# Altium schematic sheet Audio.SchDoc
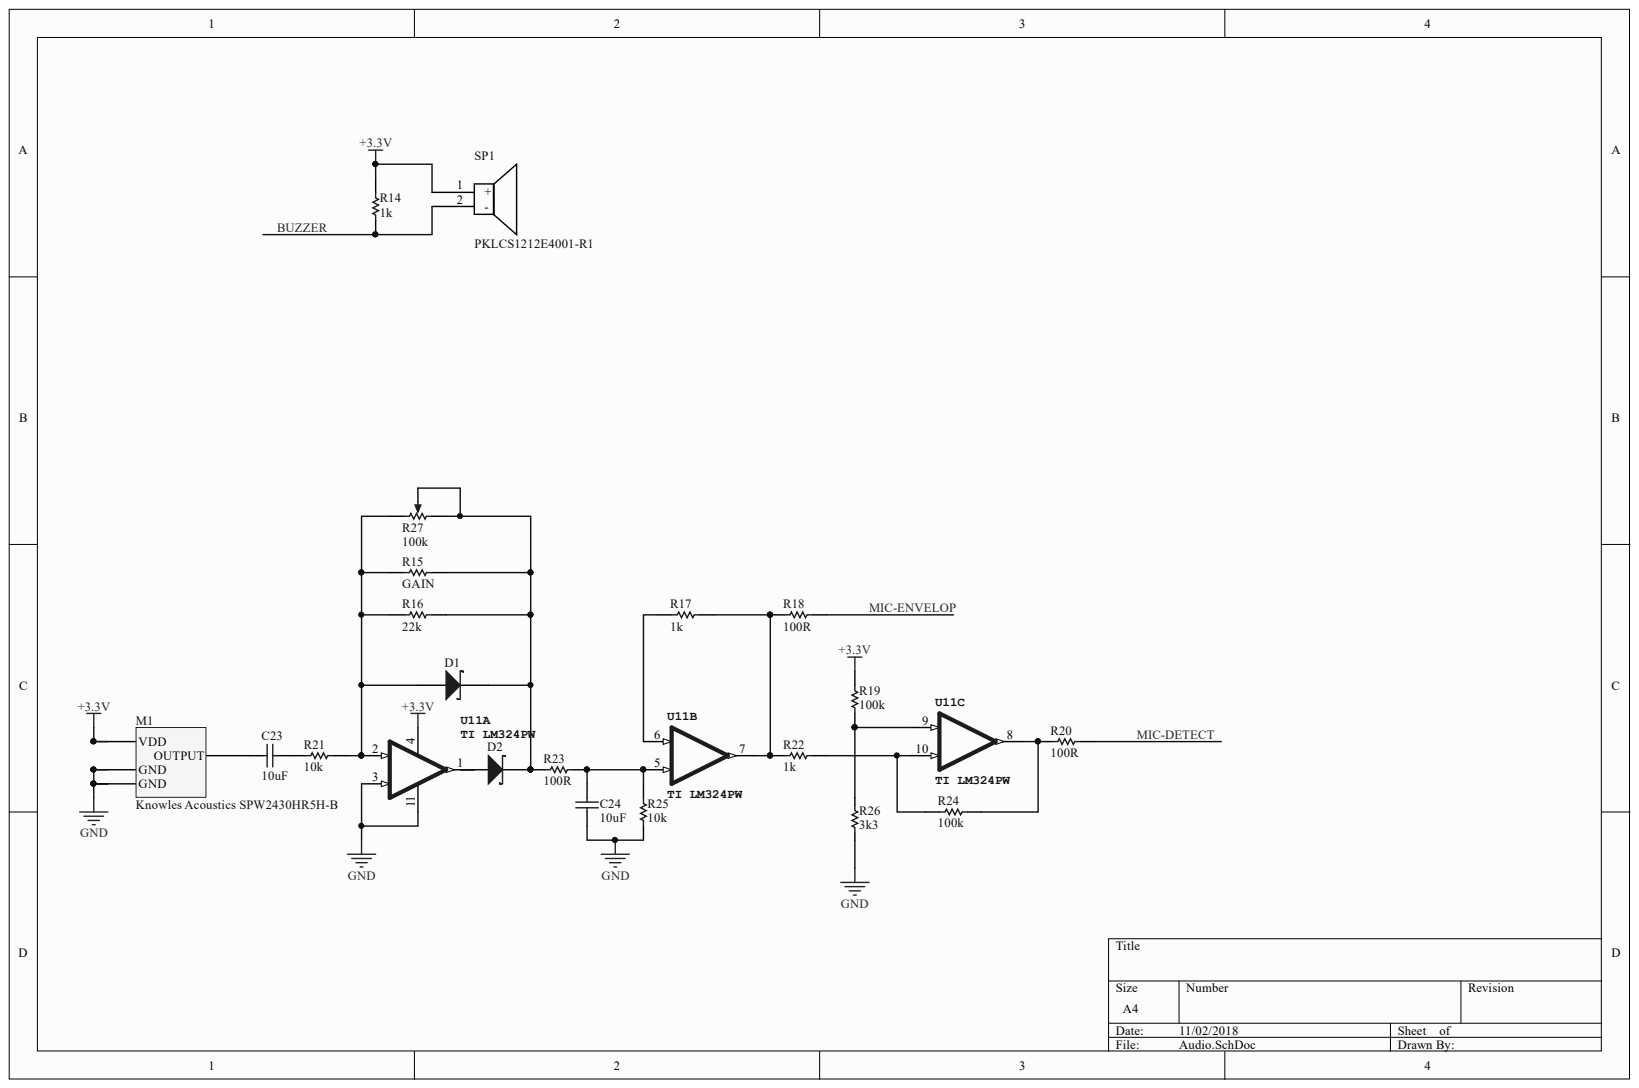
[diagram p0: Audio.SchDoc]

## Parts
C23  10uF  [CAPC1608X90X35LL15T15]
C24  10uF  [CAPC1608X90X35LL15T15]
D1  NXP Semiconductors RB751V40,115  [SOD323]  (value not drawn)
D2  NXP Semiconductors RB751V40,115  [SOD323]  (value not drawn)
M1  Knowles Acoustics SPW2430HR5H-B  Manufacturer Part Number: SPW2430HR5H-B  [PCB-q39kbiru8bm5toca3ojd-1]
R14  1k  [RESC1608X55X30LL15T15]
R15  GAIN  [RESC1609X50X30ML10T20]
R16  22k  [RESC1609X50X30ML10T20]
R17  1k  [RESC1608X55X30LL15T15]
R18  100R  [RLP101A]
R19  100k  [RESC1609X55X30ML10T20]
R20  100R  [RLP101A]
R21  10k  [PCB-a283919qkqotl3z1jwky-1]
R22  1k  [RESC1608X55X30LL15T15]
R23  100R  [RLP101A]
R24  100k  [RESC1609X55X30ML10T20]
R25  10k  [PCB-a283919qkqotl3z1jwky-1]
R26  3k3  [PCB-etnbdy2r5azyccdoncrh-1]
R27  100k  [PCB-v4ijfckvg8adpkv5862m-1]
SP1  PKLCS1212E4001-R1  [PCB-6c4bt7zzupetdyqq5q8q-1]
U11  TI LM324PW  Manufacturer Part Number: LM324PW  [TSSOP14-0.65-5X4.4MM]  (parts A,B,C)

## Nets
+3.3V: M1.4(VDD) R14.2 R19.2 SP1.1 U11.4
GND: C24.1 M1.2(GND) M1.3(GND) R25.1 R26.1 U11.3 U11.11
BUZZER: R14.1 SP1.2
MIC-DETECT: R20.2
MIC-ENVELOP: R18.2
NetC23_1: C23.1 M1.1(OUTPUT)
NetC23_2: C23.2 R21.1
NetC24_2: C24.2 R23.2 R25.2 U11.5
NetD1_1: D1.1 D2.1 R15.2 R16.2 R23.1 R27.1 R27.2
NetD1_2: D1.2 R15.1 R16.1 R21.2 R27.3 U11.2
NetD2_2: D2.2 U11.1
NetR17_1: R17.1 U11.6
NetR17_2: R17.2 R18.1 R22.1 U11.7
NetR19_1: R19.1 R26.2 U11.9
NetR20_1: R20.1 R24.2 U11.8
NetR22_2: R22.2 R24.1 U11.10

## Library data of the parts
C23 C24 = CMP-126926-2: CAP CER 10UF 4V 20% X5R 0603; Capacitance: 1e-05 F; Dielectric Material: Ceramic Multilayer; Lead-Free Status: Lead Free; Voltage Rating (DC): 4.0 V; Capacitance Tolerance: ±20%; Case/Package: 0603; Component Kind: Standard; Dielectric Characteristic: X5R; Library Name: CommunityVault; Mounting Style: Surface Mount; Number of Pins: 2; OctopartID: 91d258f991c24e15; Octopart Url: https://octopart.com/06034d106mat2a-avx+interconnect+%2F+elco-2172278; Packaging: Cut Tape (CT); REACH SVHC Compliance: No SVHC; RoHS: Compliant
D1 D2 = CMP-aee366e5113d7124-1: Diode Schottky 40V 0.12A Automotive 2-Pin SOD-323 T/R; Lead-Free Status: Lead Free; Component Kind: Standard; Library Name: CommunityVault; Lifecycle Status: Active; Manufacturer Part Number: RB751V40,115; Number of Pins: 2; OctopartID: aee366e5113d7124; Octopart Url: http://octopart.com/rb751v40%2C115-nxp+semiconductors-17603256; RoHS: Compliant; Seller Landing  Url: https://octopart-clicks.com/click/track?country=US&ak=8157f166&sig=09912e6&sid=2402&ppid=17603256&vpid=76730186&ct=offers
M1 = CMP-e6c82ce797f2390f-2: Mic Mems Analog; Component Kind: Standard; Library Name: CommunityVault; Octopart URL: http://octopart.com/spw2430hr5h-b-knowles+acoustics-52778076
R14 R17 R22 = CMP-a70b6f50b25278cc-3: RESISTOR, ANTI SULFUR, 1K, 0603, 5%; Resistance: 1kohm; Resistance Tolerance: ± 5%; Power Rating: 100mW; Voltage Rati...; Manufacturer Part Number: MCMR06X102 JTL; Resistance: 1.00 kΩ; Case/Package: 0603, 1608; Component Kind: Standard; Library Name: CommunityVault; Mounting Style: Surface Mount; OctopartID: a70b6f50b25278cc; Packaging: Cut Tape (CT); Power Rating: 100 mW; REACH SVHC Compliance: No SVHC; Resistance Tolerance: ±5%; RoHS: Compliant; Simulation: SIM-fbd72mjpv12wvr63f4ju-1
R15 R16 = CMP-1856056-2: RES SMD 22K OHM 5% 1/10W 0603; Lead-Free Status: Lead Free; Power Rating: 0.1 W; Resistance: 22000.0 Ω; Temperature Coefficient: 200.0 ppm/°C; Voltage Rating (DC): 75.0 V; Case/Package: 0603; Component Kind: Standard; Library Name: CommunityVault; Mounting Style: Surface Mount; Number of Pins: 2; OctopartID: e7772c0d1bb938b0; Octopart Url: https://octopart.com/crcw060322k0jnea-vishay-40950184; Packaging: Cut Tape (CT); Resistance Tolerance: ±5%; RoHS: Compliant; Size-Height: 0.00045 m; Size-Length: 0.00155 m; Size-Width: 0.00085 m
R18 R20 R23 = CMP-1907269-5: Generic Thick Film Resistor 0603 (1609) 1/10W; Case/Package (SI): 1608; Power Rating: 100 mW; Resistance Tolerance: ±1%; Case/Package: 0603; Component Kind: Standard; Library Name: CommunityVault; Mounting Style: Surface Mount; OctopartID: f76f3c3c26c528a8; Packaging: Cut Tape (CT), Tape & Reel (TR); Size-Height: 11.4 mm; Size-Length: 39.4 mm
R19 R24 = CMP-1863112-2: RES SMD 100K OHM 0.1% 1/10W 0603; Lead-Free Status: Lead Free; Power Rating: 0.1 W; Resistance: 100000.0 Ω; Voltage Rating (DC): 75.0 V; Case/Package: 0603; Component Kind: Standard; Library Name: CommunityVault; OctopartID: c79f0c101ea61858; Octopart Url: https://octopart.com/tnpw0603100kbeea-vishay-42335327; REACH SVHC Compliance: No SVHC; Resistance Tolerance: ±0.1%; RoHS: Compliant
R21 R25 = CMP-9d25557e880342e2-1: RESISTOR, ANTI SULFUR, 10K, 0603, 5%; Resistance: 10kohm; Resistance Tolerance: ± 5%; Power Rating: 100mW; Voltage Ra...; Manufacturer Part Number: MCMR06X103 JTL; Case/Package (SI): 1608; Resistance: 10.0 kΩ; Voltage Rating (DC): 75.0 V; Case/Package: 0603, 1608; Component Kind: Standard; Library Name: CommunityVault; Mounting Style: Surface Mount; OctopartID: 9d25557e880342e2; Octopart URL: http://octopart.com/mcmr06x103+jtl-multicomp-22097984; Packaging: Tape & Reel (TR); Power Rating: 100 mW; REACH SVHC Compliance: No SVHC; RoHS: Compliant
R26 = CMP-b12b7aab182d6eec-2: Surface Mount Chip Resistor, Thick Film, AEC-Q200 CRCW Series, 3.3 kohm, 250 mW, 1%, 75 V; Power Rating: 100 mW; Component Kind: Standard; Library Name: CommunityVault
R27 = CMP-9cc655c47f974301-2: Res Trimmer 100K Ohm 25% 3/20W 1(Elec)/1(Mech)Turn (3 X 3.75 X 1.55mm) Foot Print SMD Embossed T/R; Manufacturer Part Number: 3303W-3-104E; Color: Silver; Component Kind: Standard; Library Name: CommunityVault; OctopartID: 9cc655c47f974301; Octopart URL: http://octopart.com/3303w-3-104e-bourns-575031; RoHS: Compliant; Size-Diameter: 3.10 mm; Size-Height: 1.62 mm
SP1 = CMP-e26d2b6ed2763daa-4: PK Series 12 x 12 x 3 mm 3 V 4 KHz Surface Mount Piezoelectric Sounder; Lead-Free Status: Lead Free; Resonant Frequency: 4.00 kHz; Supply Voltage (DC): 25.0 V (max); Voltage Rating (DC): 25.0 V; Component Kind: Standard; Library Name: CommunityVault; Manufacturer Part Number: PKLCS1212E4001-R1; Mounting Style: Surface Mount; OctopartID: e26d2b6ed2763daa; Octopart URL: http://octopart.com/pklcs1212e4001-r1-murata-595325; Packaging: Cut Tape (CT); REACH SVHC Compliance: Contains SVHC; RoHS: Compliant; Self Resonant Frequency: 4.00 kHz; Size-Diameter: 12.0 mm
U11 = CMP-2a7fe364fdcbf5b8-1: IC OPAMP LM324/TSSOP/LM324PW TEXAS INSTRUMENT RoHS TSSOP14; Bandwidth: 1200000.0 Hz; Input Offset Drift: 7e-06 V/K; Lead-Free Status: Lead Free; Number of Channels: 4; Slew Rate: 0.5 V/μs; Supply Voltage (DC): 15.0 V; Component Kind: Standard; Gain Bandwidth Product: 1200000.0 Hz; Library Name: CommunityVault; Lifecycle Status: Active; Number of Pins: 14; OctopartID: 2a7fe364fdcbf5b8; Octopart Url: http://octopart.com/lm324pw-texas+instruments-1176274; Packaging: Tube; REACH SVHC Compliance: No SVHC; RoHS: Compliant; Seller Landing  Url: https://octopart-clicks.com/click/track?country=US&ak=8157f166&sig=075793c&sid=5489&ppid=1176274&vpid=38506494&ct=offers
